# Altium schematic sheet Esquematico.SchDoc
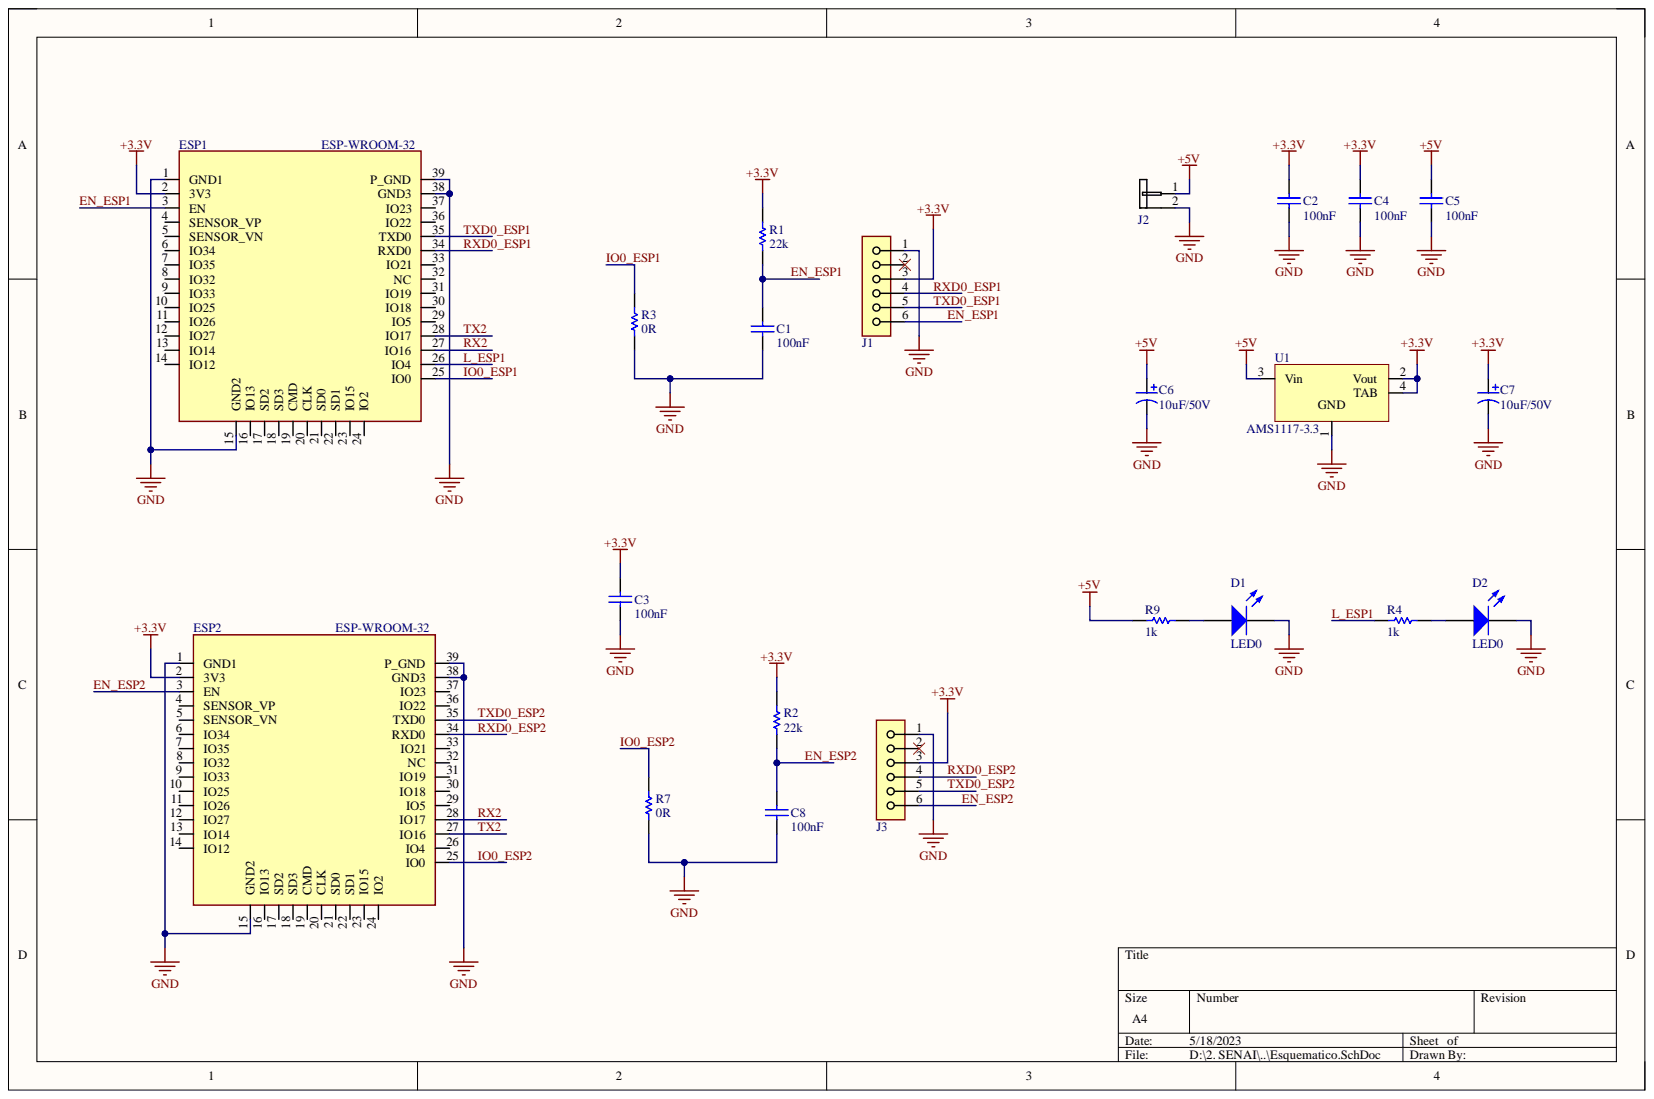
[diagram p0: Esquematico.SchDoc]

## Parts
C1  value 100nF  [6-0805_M]  (hidden comment: Cap)
C2  value 100nF  [6-0805_M]  (hidden comment: Cap)
C3  value 100nF  [6-0805_M]  (hidden comment: Cap)
C4  value 100nF  [6-0805_M]  (hidden comment: Cap)
C5  value 100nF  [6-0805_M]  (hidden comment: Cap)
C6  value 10uF/50V  [C1206]  (hidden comment: CAP_ELEC)
C7  value 10uF/50V  [C1206]  (hidden comment: CAP_ELEC)
C8  value 100nF  [6-0805_M]  (hidden comment: Cap)
D1  LED0  [6-0805_M]
D2  LED0  [6-0805_M]
ESP1  ESP-WROOM-32  [ESP32-WROOM-32D]
ESP2  ESP-WROOM-32  [ESP32-WROOM-32D]
J1  SIL_6  [SIL_6]  (value not drawn)
J2  JACK_J4  [JACK_J4]  (value not drawn)
J3  SIL_6  [SIL_6]  (value not drawn)
R1  value 22k  [6-0805_M]  (hidden comment: Res1)
R2  value 22k  [6-0805_M]  (hidden comment: Res1)
R3  value 0R  [6-0805_M]  (hidden comment: Res1)
R4  value 1k  [6-0805_M]  (hidden comment: Res1)
R7  value 0R  [6-0805_M]  (hidden comment: Res1)
R9  value 1k  [6-0805_M]  (hidden comment: Res1)
U1  AMS1117-3.3  [SOT223_M]

## Nets
+3.3V: C2.2 C3.2 C4.2 C7.1 ESP1.2(3V3) ESP2.2(3V3) J1.3 J3.3 R1.2 R2.2 U1.2(Vout) U1.4(TAB)
+5V: C5.2 C6.1 J2.1 R9.2 U1.3(Vin)
GND: C1.1 C2.1 C3.1 C4.1 C5.1 C6.2 C7.2 C8.1 D1.2 D2.2 ESP1.1(GND1) ESP1.15(GND2) ESP1.38(GND3) ESP1.39(P_GND) ESP2.1(GND1) ESP2.15(GND2) ESP2.38(GND3) ESP2.39(P_GND) J1.1 J2.2 J3.1 R3.1 R7.1 U1.1
EN_ESP1: C1.2 ESP1.3(EN) J1.6 R1.1
EN_ESP2: C8.2 ESP2.3(EN) J3.6 R2.1
IO0_ESP1: ESP1.25(IO0) R3.2
IO0_ESP2: ESP2.25(IO0) R7.2
L_ESP1: ESP1.26(IO4) R4.2
RX2: ESP1.27(IO16) ESP2.28(IO17)
RXD0_ESP1: ESP1.34(RXD0) J1.4
RXD0_ESP2: ESP2.34(RXD0) J3.4
TX2: ESP1.28(IO17) ESP2.27(IO16)
TXD0_ESP1: ESP1.35(TXD0) J1.5
TXD0_ESP2: ESP2.35(TXD0) J3.5
NetD1_1: D1.1 R9.1
NetD2_1: D2.1 R4.1

Not connected: ESP1 4(SENSOR_VP) 5(SENSOR_VN) 6(IO34) 7(IO35) 8(IO32) 9(IO33) 10(IO25) 11(IO26) 12(IO27) 13(IO14) 14(IO12) 16(IO13) 17(SD2) 18(SD3) 19(CMD) 20(CLK) 21(SD0) 22(SD1) 23(IO15) 24(IO2) 29(IO5) 30(IO18) 31(IO19) 32(NC) 33(IO21) 36(IO22) 37(IO23); ESP2 4(SENSOR_VP) 5(SENSOR_VN) 6(IO34) 7(IO35) 8(IO32) 9(IO33) 10(IO25) 11(IO26) 12(IO27) 13(IO14) 14(IO12) 16(IO13) 17(SD2) 18(SD3) 19(CMD) 20(CLK) 21(SD0) 22(SD1) 23(IO15) 24(IO2) 26(IO4) 29(IO5) 30(IO18) 31(IO19) 32(NC) 33(IO21) 36(IO22) 37(IO23); J1 2; J3 2

## Library data of the parts
C1-C5 C8 = Cap: Capacitor; Component Kind: Standard; LatestRevisionDate: 17-Jul-2002; LatestRevisionNote: Re-released for DXP Platform.; Library Name: Miscellaneous Devices.IntLib; PackageDescription: Radial Cap, Thru-Hole; 2 Leads; 0.3 in Pin Spacing; PackageReference: RAD-0.3; Published: 8-Jun-2000; Signal Integrity: Cap; Simulation: CAP; Publisher: Altium Limited
C6 C7 = CAP_ELEC: Capacitor eletrolitico radial; Component Kind: Standard; Library Name: Componentes_SENAI.IntLib
D1 D2 = LED0: Typical INFRARED GaAs LED; Component Kind: Standard; LatestRevisionDate: 08-Jul-2005; LatestRevisionNote: Mech Layer 1 removed.; Library Name: Miscellaneous Devices.IntLib; PackageDescription: LED; 2 Leads; PackageReference: LED-0; Published: 8-Jun-2000; Simulation: LED0; Publisher: Altium Limited
J2 = JACK_J4: JACK P4; Component Kind: Standard; Library Name: Componentes_SENAI.IntLib
R1-R4 R7 R9 = Res1: Resistor; Component Kind: Standard; LatestRevisionDate: 17-Jul-2002; LatestRevisionNote: Re-released for DXP Platform.; Library Name: Miscellaneous Devices.IntLib; PackageDescription: Axial Device, Thru-Hole; 2 Leads; 0.3 in Pin Spacing; PackageReference: AXIAL-0.3; Published: 8-Jun-2000; Signal Integrity: Res; Simulation: RESISTOR; Publisher: Altium Limited
U1 = AMS1117-3.3: 1A LOW DROPOUT VOLTAGE REGULATOR; Component Kind: Standard; Library Name: Componentes_SENAI.IntLib
ESP1 ESP2 = ESP-WROOM-32: Component Kind: Standard; Description: ESP-WROOM-32; Library Name: Componentes_SENAI.IntLib
J1 J3 = SIL_6: Component Kind: Standard; Description: SIL_6; Library Name: Componentes_SENAI.IntLib
